# Altium schematic sheet Teensy with Compass V2.SchDoc("Teensy with Compass V2")
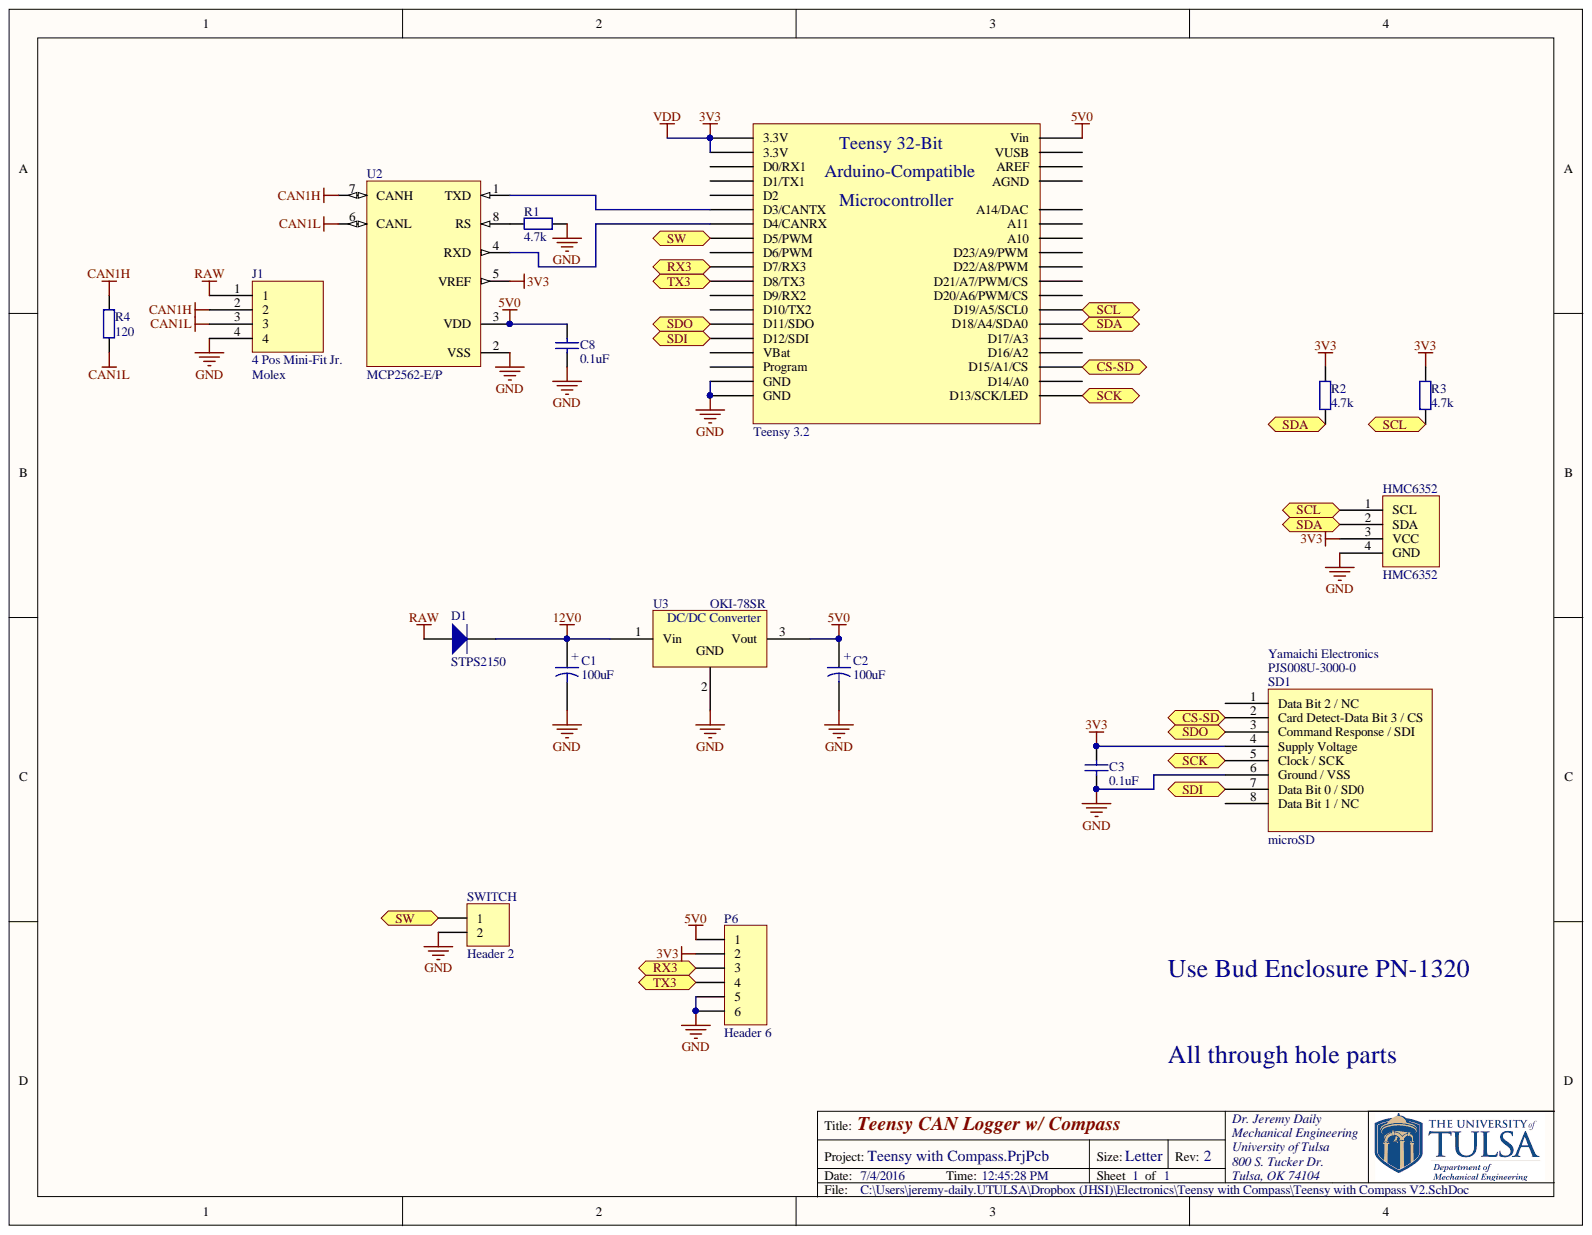
[diagram p0: Teensy with Compass V2.SchDoc]

## Parts
C1  100uF  [Elec. Cap 5 x 10mm]
C2  100uF  [Elec. Cap 5 x 10mm]
C3  0.1uF  [RAD-0.2]
C8  0.1uF  [RAD-0.2]
D1  STPS2150  [DO-41]
HMC6352  HMC6352  [HMC6325]
J1  4 Pos Mini-Fit Jr.  Manufacturer: Molex  [4 POS Vertical MINI-FIT JR]
P6  Header 6  [HDR1X6]
R1  4.7k  [AXIAL-0.4]
R2  4.7k  [AXIAL-0.4]
R3  4.7k  [AXIAL-0.4]
R4  120  [AXIAL-0.4]
SD1  microSD  PartNumber: PJS008U-3000-0  [SD- Vertical]
SWITCH  Header 2  [HDR1X2]
Teensy_3.2  Teensy 3.2  [Teensy]
U2  MCP2562-E/P  [PDIP300-P8]
U3  OKI-78SR  [78xx]

## Ports
CS-SD, RX3, SCK, SCL, SDA, SDI, SDO, SW, TX3

## Nets
3V3: C3.2 HMC6352.3(VCC) P6.2 R2.2 R3.2 SD1.4(Supply_Voltage) Teensy_3.2.16(3.3V) Teensy_3.2.31(3.3V) U2.5(VREF)
5V0: C2.1 C8.2 P6.1 Teensy_3.2.33(Vin) U2.3(VDD) U3.3
12V0: C1.1 D1.2 U3.1
CAN1H: J1.2 R4.2 U2.7(CANH)
CAN1L: J1.3 R4.1 U2.6(CANL)
GND: C1.2 C2.2 C3.1 C8.1 HMC6352.4(GND) J1.4 P6.5 P6.6 R1.1 SD1.6(Ground_/_VSS) SWITCH.2 Teensy_3.2.14(GND) Teensy_3.2.17(GND) U2.2(VSS) U3.2
RAW: D1.1 J1.1
CS-SD: SD1.2(Card_Detect-Data_Bit_3_/_CS) Teensy_3.2.22(D15/A1/CS) ; port CS-SD
RX3: P6.3 Teensy_3.2.6(D7/RX3) ; port RX3
SCK: SD1.5(Clock_/_SCK) Teensy_3.2.20(D13/SCK/LED) ; port SCK
SCL: HMC6352.1(SCL) R3.1 Teensy_3.2.26(D19/A5/SCL0) ; port SCL
SDA: HMC6352.2(SDA) R2.1 Teensy_3.2.25(D18/A4/SDA0) ; port SDA
SDI: SD1.7(Data_Bit_0_/_SD0) Teensy_3.2.1(D12/SDI) ; port SDI
SDO: SD1.3(Command_Response_/_SDI) Teensy_3.2.2(D11/SDO) ; port SDO
SW: SWITCH.1 Teensy_3.2.8(D5/PWM) ; port SW
TX3: P6.4 Teensy_3.2.5(D8/TX3) ; port TX3
NetR1_2: R1.2 U2.8(RS)
NetTeensy 3.2_3: Teensy_3.2.3(D10/TX2)
NetTeensy 3.2_4: Teensy_3.2.4(D9/RX2)
NetTeensy 3.2_7: Teensy_3.2.7(D6/PWM)
NetTeensy 3.2_9: Teensy_3.2.9(D4/CANRX) U2.4(RXD)
NetTeensy 3.2_10: Teensy_3.2.10(D3/CANTX) U2.1(TXD)
NetTeensy 3.2_11: Teensy_3.2.11(D2)
NetTeensy 3.2_12: Teensy_3.2.12(D1/TX1)
NetTeensy 3.2_13: Teensy_3.2.13(D0/RX1)
NetTeensy 3.2_15: Teensy_3.2.15(VBat)
NetTeensy 3.2_21: Teensy_3.2.21(D14/A0)
NetTeensy 3.2_23: Teensy_3.2.23(D16/A2)
NetTeensy 3.2_24: Teensy_3.2.24(D17/A3)
NetTeensy 3.2_27: Teensy_3.2.27(D20/A6/PWM/CS)
NetTeensy 3.2_28: Teensy_3.2.28(D21/A7/PWM/CS)
NetTeensy 3.2_29: Teensy_3.2.29(D22/A8/PWM)
NetTeensy 3.2_30: Teensy_3.2.30(D23/A9/PWM)

Not connected: SD1 1(Data_Bit_2_/_NC) 8(Data_Bit_1_/_NC); Teensy_3.2 18(Program) 19(A14/DAC) 32(AGND) 34(VUSB) 35(AREF) 36(A10) 37(A11)

## Library data of the parts
C1 C2 = Electrolytic Cap: CAP ALUM 100UF 20% 63V RADIAL; Manufacturer: Panasonic Electronic Components; PartNumber: EEU-FC1J101; Component Kind: Standard; Library Name: SSS Integrated Library.IntLib; Supplier 1: Digi-Key; Supplier Part Number 1: P10343-ND
C3 C8 = Cap: CAP CER 0.1UF 50V 10% X7R 0603; Manufacturer: AVX; PartNumber: SR215C104KAA; Component Kind: Standard; Library Name: SSS Schematic Library.SchLib; Signal Integrity: Cap; Simulation: CAP; Supplier 1: Mouser; Supplier Part Number 1: 581-SR215C104K
D1 = Diode 1N4003: 1 Amp General Purpose Rectifier; Manufacturer: STMicroelectronics; Component Kind: Standard; Library Name: Miscellaneous Devices.IntLib; PartNumber: STPS2150; Signal Integrity: 1N4003; Simulation: 1N4003; Supplier 1: Mouser; Supplier Part Number 1: 511-STPS2150
HMC6352 = HMC6352: Sparkfun Compass Module - HMC6352  SEN-07915; Component Kind: Standard; Library Name: SSS Schematic Library.SchLib
J1 = 4 Pos Header Vert: CONN HEADER 4POS 4.2MM VERT TIN; Component Kind: Standard; Library Name: Molex Mini-Fit Jr.IntLib; PartNumber: 39-29-9043; Supplier 1: Mouser; Supplier Part Number 1: 538-39-29-9043
P6 = Header 6: Header, 6-Pin; Component Kind: Standard; Library Name: Miscellaneous Connectors.IntLib; Signal Integrity: Connector
R1-R3 = Res2: Resistor; Manufacturer: Stackpole Electronics Inc.; PartNumber: CF14JT4K70; Component Kind: Standard; Library Name: Miscellaneous Devices.IntLib; Signal Integrity: Res; Simulation: RESISTOR; Supplier 1: Digi-Key; Supplier Part Number 1: CF14JT4K70TR-ND
R4 = Res2: Resistor; Manufacturer: Stackpole Electronics Inc.; PartNumber: CF14JT120R; Component Kind: Standard; Library Name: Miscellaneous Devices.IntLib; Signal Integrity: Res; Simulation: RESISTOR; Supplier 1: Digi-Key; Supplier Part Number 1: CF14JT120RCT-ND
SD1 = SD Card Holder Vertical: Memory Card Connectors MicroSD Card Conn Ver Dip Mnt Manual; Manufacturer: Yamaichi Electronics; Component Kind: Standard; Library Name: SSS Integrated Library.IntLib; Supplier 1: Mouser; Supplier Part Number 1: 945-PJS008U-3000-0
SWITCH = Header 2: Header, 2-Pin; Component Kind: Standard; Library Name: Miscellaneous Connectors.IntLib; Signal Integrity: Connector
Teensy_3.2 = Teensy: 32 Bit Arduino-Compatible Microcontroller; Manufacturer: PRJC; Component Kind: Standard; Library Name: SSS Integrated Library.IntLib; PartNumber: Teensy 3.2; Supplier 1: Sparkfun; Supplier Part Number 1: DEV-13736
U2 = MCP2551-E/P: High-Speed CAN Transceiver, 8-Pin PDIP, Extended Temperature; Manufacturer: Microchip; Component Kind: Standard; Library Name: Microchip Interface.IntLib; PartNumber: MCP2562-E/P; Supplier 1: Digi-Key; Supplier Part Number 1: MCP2562-E/P-ND
U3 = 7805: 5V DC/DC Converter (7805 replacement); Manufacturer: Murata Power Solutions Inc.; PartNumber: OKI-78SR-5/1.5-W36-C; Component Kind: Standard; Library Name: SSS Integrated Library.IntLib; Supplier 1: Digi-Key; Supplier Part Number 1: 811-2196-5-ND
